# Altium schematic sheet EE3951W_Scale.SchDoc
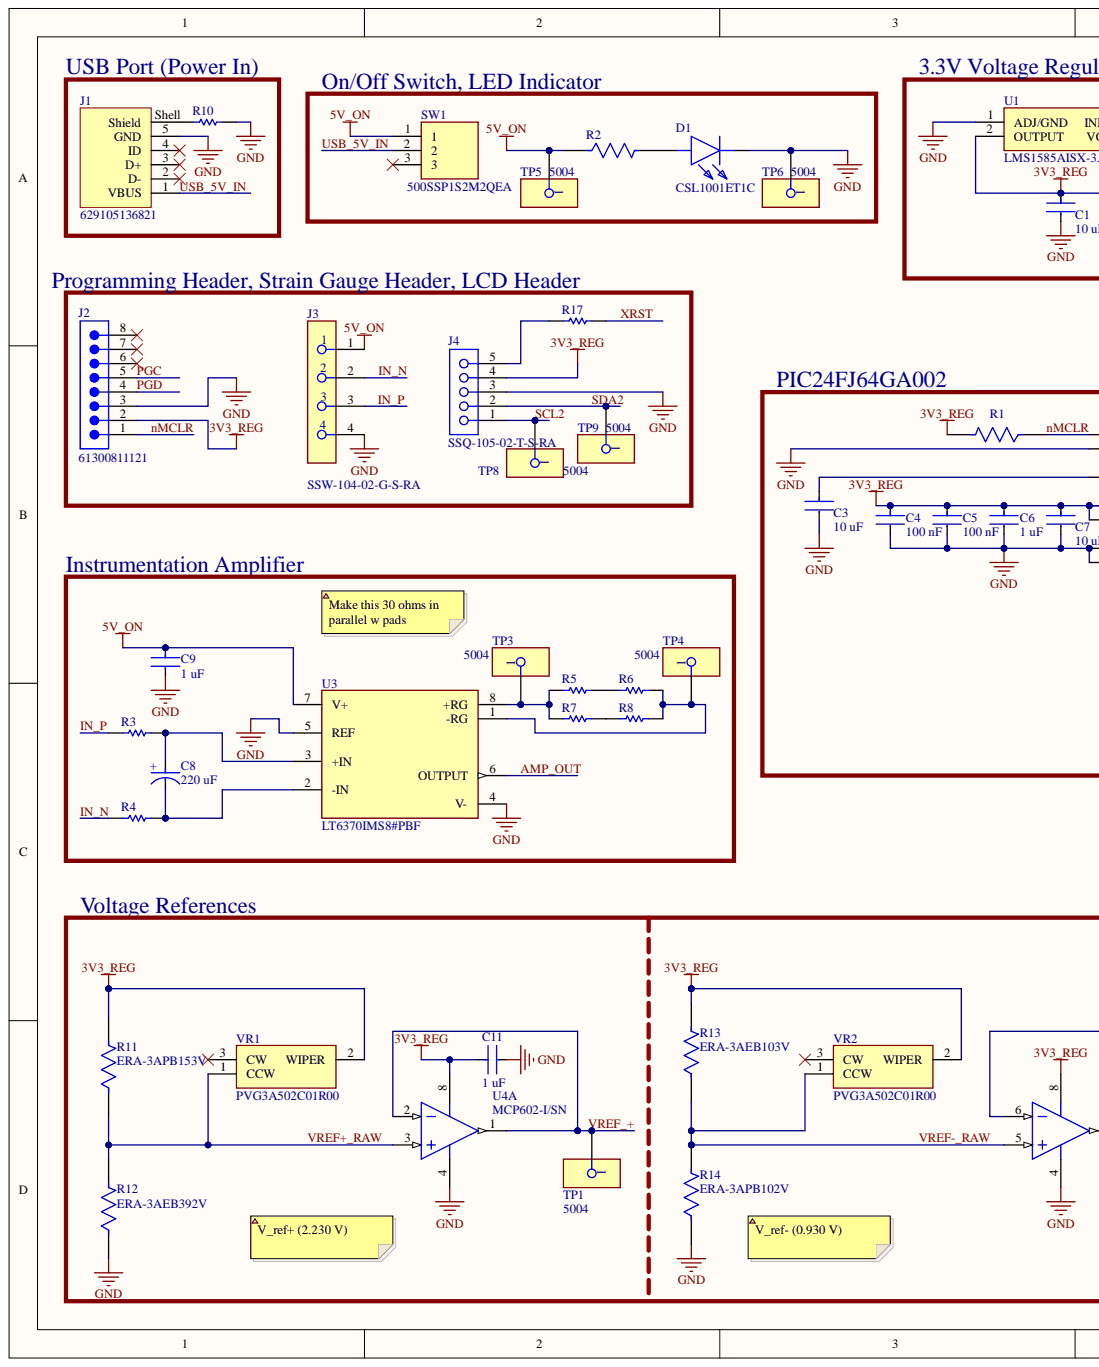
[diagram p0: EE3951W_Scale.SchDoc, left]
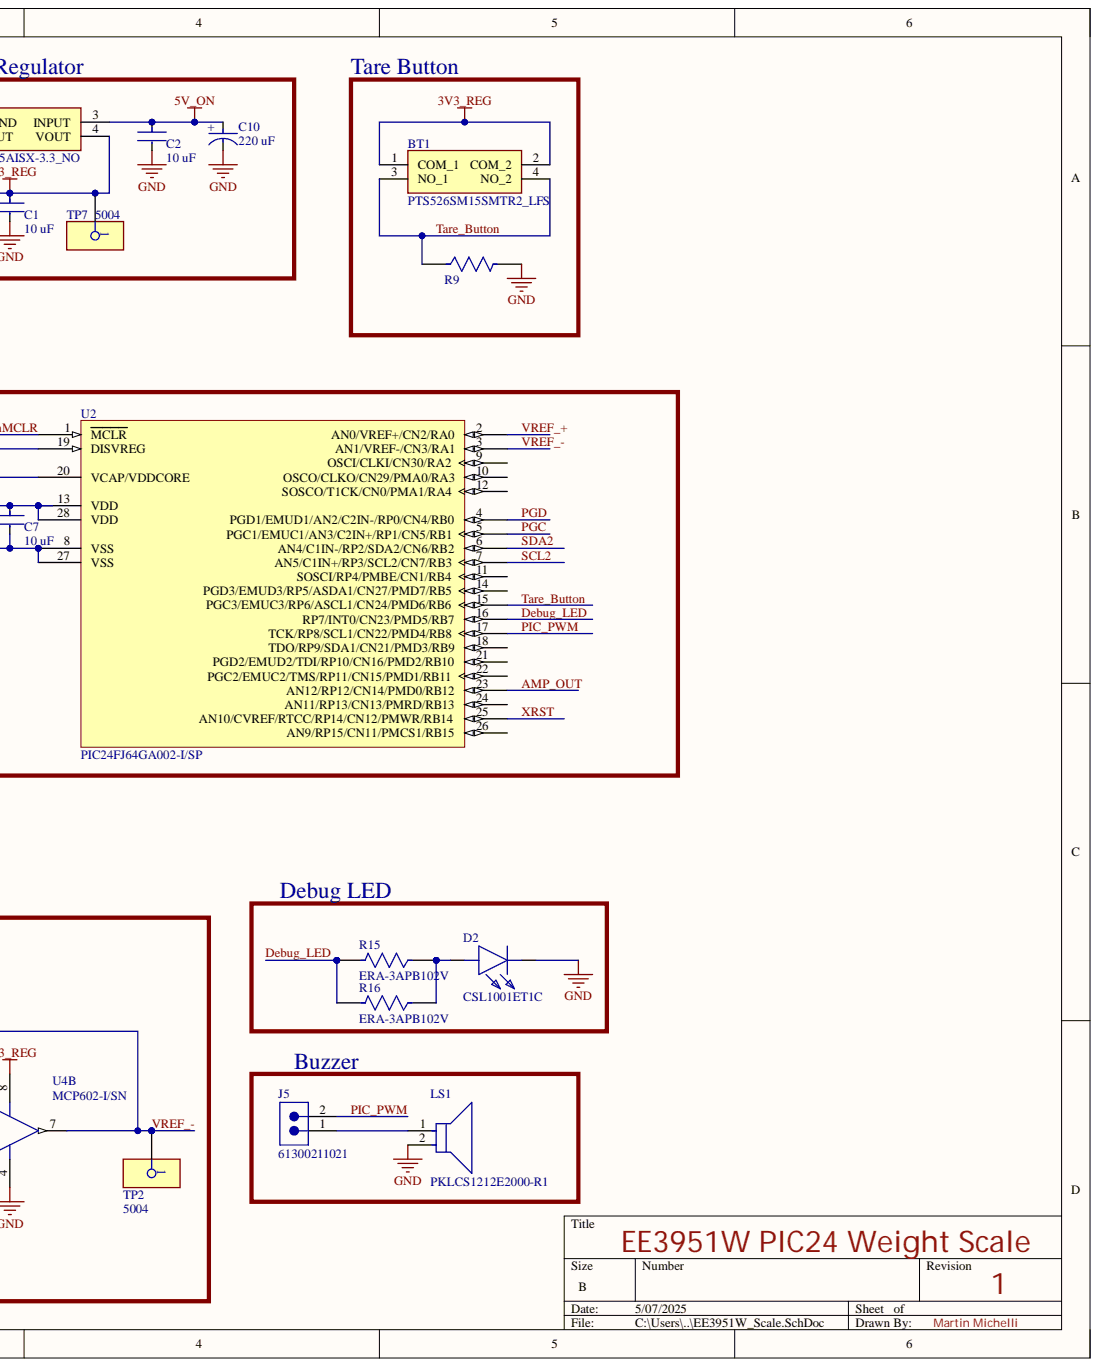
[diagram p1: EE3951W_Scale.SchDoc, right]

## Parts
BT1  PTS526SM15SMTR2_LFS  [PTS526SM15SMTR2LFS]
C1  10 uF  [FP-GRM188-0_1-e0_2_0_55-IPC_A]
C2  10 uF  [FP-GRM188-0_1-e0_2_0_55-IPC_A]
C3  10 uF  [FP-GRM188-0_1-e0_2_0_55-IPC_A]
C4  100 nF  [FP-GRM188-0_1-e0_2_0_5-IPC_B]
C5  100 nF  [FP-GRM188-0_1-e0_2_0_5-IPC_B]
C6  1 uF  [FP-GCM188-0_1-MFG]
C7  10 uF  [FP-GRM188-0_1-e0_2_0_55-IPC_A]
C8  220 uF  [FP-TAJC-MFG]
C9  1 uF  [FP-GCM188-0_1-MFG]
C10  220 uF  [FP-TAJC-MFG]
C11  1 uF  [FP-GCM188-0_1-MFG]
D1  CSL1001ET1C  [CSL1001ET1C]
D2  CSL1001ET1C  [CSL1001ET1C]
J1  629105136821  Connector Type: USB  [629105136821]
J2  61300811121  [61300811121]
J3  SSW-104-02-G-S-RA  [FP-SSW-104-02-G-S-RA-MFG]
J4  SSQ-105-02-T-S-RA  [SMTC-SSQ-105-02-X-S-RA]
J5  61300211021  [61300211021]
LS1  PKLCS1212E2000-R1  [FP-PKLCS1212E2000-R1-MFG]
R1  RC0603FR-0710KL  [FP-RC0603-0_55-IPC_C]  (value not drawn)
R2  RC0603FR-071KL  [FP-RC0603-0_55-IPC_C]  (value not drawn)
R3  RC0603JR-070RL  [RESC1608X55X25ML10T15]  (value not drawn)
R4  RC0603JR-070RL  [RESC1608X55X25ML10T15]  (value not drawn)
R5  RC0603JR-070RL  [RESC1608X55X25ML10T15]  (value not drawn)
R6  RC0603JR-070RL  [RESC1608X55X25ML10T15]  (value not drawn)
R7  RC0603JR-070RL  [RESC1608X55X25ML10T15]  (value not drawn)
R8  RC0603JR-070RL  [RESC1608X55X25ML10T15]  (value not drawn)
R9  RC0603FR-0710KL  [FP-RC0603-0_55-IPC_C]  (value not drawn)
R10  RC0603JR-070RL  [RESC1608X55X25ML10T15]  (value not drawn)
R11  ERA-3APB153V  [FP-ERA3A-IPC_C]
R12  ERA-3AEB392V  [FP-ERA3A-IPC_C]
R13  ERA-3AEB103V  [FP-ERA3A-IPC_C]
R14  ERA-3APB102V  [FP-ERA3A-IPC_C]
R15  ERA-3APB102V  [FP-ERA3A-IPC_C]
R16  ERA-3APB102V  [FP-ERA3A-IPC_C]
R17  RC0603JR-070RL  [RESC1608X55X25ML10T15]  (value not drawn)
SW1  500SSP1S2M2QEA  [500SSP1S2M2QEA]
TP1  5004  [FP-5004-MFG]
TP2  5004  [FP-5004-MFG]
TP3  5004  [FP-5004-MFG]
TP4  5004  [FP-5004-MFG]
TP5  5004  [FP-5004-MFG]
TP6  5004  [FP-5004-MFG]
TP7  5004  [FP-5004-MFG]
TP8  5004  [FP-5004-MFG]
TP9  5004  [FP-5004-MFG]
U1  LMS1585AISX-3.3_NO  [KTT0003B]
U2  PIC24FJ64GA002-I/SP  [SPDIP300-SP28]  (value not drawn)
U3  LT6370IMS8#PBF  [FP-MS8-8-05-08-1660-IPC_B]
U4  MCP602-I/SN  [SOIC-SN8_M]  (parts A,B)
VR1  PVG3A502C01R00  [PVG3A502C01R00]
VR2  PVG3A502C01R00  [PVG3A502C01R00]

## Nets
3V3_REG: BT1.1(COM_1) BT1.2(COM_2) C1.1 C4.1 C5.1 C6.1 C7.1 C11.1 J2.2 J4.4 R1.2 R11.2 R13.2 TP7.1 U1.2(OUTPUT) U1.4(VOUT) U2.13(VDD) U2.28(VDD) U4.8 VR1.2 VR2.2
5V_ON: C2.1 C9.1 C10.2 J3.1 R2.1 SW1.1 TP5.1 U1.3(INPUT) U3.7(V+)
GND: C1.2 C2.2 C3.2 C4.2 C5.2 C6.2 C7.2 C9.2 C10.1 C11.2 D1.1 D2.1 J1.5(GND) J2.3 J3.4 J4.3 LS1.2 R9.2 R10.2 R12.1 R14.1 TP6.1 U1.1(ADJ/GND) U2.8(VSS) U2.19(DISVREG) U2.27(VSS) U3.4(V-) U3.5(REF) U4.4
AMP_OUT: U2.23(AN12/RP12/CN14/PMD0/RB12) U3.6(OUTPUT)
Debug_LED: R15.2 R16.2 U2.16(RP7/INT0/CN23/PMD5/RB7)
IN_N: J3.2 R4.1
IN_P: J3.3 R3.1
nMCLR: J2.1 R1.1 U2.1(MCLR)
PGC: J2.5 U2.5(PGC1/EMUC1/AN3/C2IN+/RP1/CN5/RB1)
PGD: J2.4 U2.4(PGD1/EMUD1/AN2/C2IN-/RP0/CN4/RB0)
PIC_PWM: J5.2 U2.17(TCK/RP8/SCL1/CN22/PMD4/RB8)
SCL2: J4.1 TP8.1 U2.7(AN5/C1IN+/RP3/SCL2/CN7/RB3)
SDA2: J4.2 TP9.1 U2.6(AN4/C1IN-/RP2/SDA2/CN6/RB2)
Tare_Button: BT1.3(NO_1) BT1.4(NO_2) R9.1 U2.15(PGC3/EMUC3/RP6/ASCL1/CN24/PMD6/RB6)
USB_5V_IN: J1.1(VBUS) SW1.2
VREF+_RAW: R11.1 R12.2 U4.3 VR1.1
VREF-_RAW: R13.1 R14.2 U4.5 VR2.1
VREF_+: TP1.1 U2.2(AN0/VREF+/CN2/RA0) U4.1 U4.2
VREF_-: TP2.1 U2.3(AN1/VREF-/CN3/RA1) U4.6 U4.7
XRST: R17.2 U2.25(AN10/CVREF/RTCC/RP14/CN12/PMWR/RB14)
NetC3_1: C3.1 U2.20(VCAP/VDDCORE)
NetC8_1: C8.1 R4.2 U3.2(-IN)
NetC8_2: C8.2 R3.2 U3.3(+IN)
NetD1_2: D1.2 R2.2
NetD2_2: D2.2 R15.1 R16.1
NetJ1_Shell: J1.Shell(Shield) R10.1
NetJ4_5: J4.5 R17.1
NetJ5_1: J5.1 LS1.1
NetR5_1: R5.1 R7.1 TP3.1 U3.8(+RG)
NetR5_2: R5.2 R6.1
NetR6_2: R6.2 R8.2 TP4.1 U3.1(-RG)
NetR7_2: R7.2 R8.1

Not connected: J1 2(D-) 3(D+) 4(ID); J2 6 7 8; SW1 3; U2 9(OSCI/CLKI/CN30/RA2) 10(OSCO/CLKO/CN29/PMA0/RA3) 11(SOSCI/RP4/PMBE/CN1/RB4) 12(SOSCO/T1CK/CN0/PMA1/RA4) 14(PGD3/EMUD3/RP5/ASDA1/CN27/PMD7/RB5) 18(TDO/RP9/SDA1/CN21/PMD3/RB9) 21(PGD2/EMUD2/TDI/RP10/CN16/PMD2/RB10) 22(PGC2/EMUC2/TMS/RP11/CN15/PMD1/RB11) 24(AN11/RP13/CN13/PMRD/RB13) 26(AN9/RP15/CN11/PMCS1/RB15); VR1 3; VR2 3

## Library data of the parts
BT1 = PTS526SM15SMTR2_LFS: Switch; Manufacturer_Name: C & K COMPONENTS; Manufacturer_Part_Number: PTS526SM15SMTR2 LFS; Component Kind: Standard; Datasheet Link: https://www.ckswitches.com/media/2780/pts526.pdf; Height: 1.65mm
C1-C3 C7 = CMP-2006-02792-2: [GRM188R60J106ME47D] Chip Multilayer Ceramic Capacitors for General Purpose, 0603, 10uF, X5R, 15%, 20%, 6.3V; Capacitance: 10uF; Case Code (Imperial): 0603; Case Code (Metric): 1608; Case/Package: 0603; Component Kind: Standard; Depth: 0.8mm; Dielectric: X5R; Height: 0.8mm; Lead Free: Lead Free; Length: 1.6mm; Library Name: Altium Content Vault; Material: Ceramic; Max Operating Temperature: 85°C; Min Operating Temperature: -55°C; Mount: Surface Mount; Package Quantity: 4000; Packaging: Tape and Reel; Radiation Hardening: No; REACH SVHC: No SVHC; Termination: SMD/SMT; Thickness: 0.035inch; Tolerance: 20%; Voltage: 6.3V; Voltage Rating: 6.3V; Voltage Rating (DC): 6.3V; Width: 0.031inch
C4 C5 = CMP-1035-02595-8: [GRM188R72A104KA35D] Chip Multilayer Ceramic Capacitors for General Purpose, 0603, 0.10uF, X7R, 15%, 10%, 100V; Capacitance: 100nF; Case Code (Imperial): 0603; Case Code (Metric): 1608; Case/Package: 0603; Component Kind: Standard; Depth: 0.8mm; Dielectric: X7R; Height: 0.8mm; Lead Free: Lead Free; Length: 1.6mm; Library Name: Altium Content Vault; Material: Ceramic; Max Operating Temperature: 125°C; Min Operating Temperature: -55°C; Mount: Surface Mount; Package Quantity: 1; Packaging: Tape and Reel; Radiation Hardening: No; REACH SVHC: No SVHC; Termination: SMD/SMT; Thickness: 0.035inch; Tolerance: 10%; Voltage: 100V; Voltage Rating: 100V; Voltage Rating (DC): 100V; Weight: 0.000222oz; Width: 0.8mm
C6 C9 C11 = CMP-2006-02363-4: [GCM188R71E105KA64D] 1 µF ±10% 25V Ceramic Capacitor X7R 0603 (1608 Metric); Manufacturer Lifecycle Status: IN PRODUCTION; NexarPartId: 18909256; Capacitance: 1µF; Case Code (Imperial): 0603; Case Code (Metric): 1608; Case/Package: 0603; Component Kind: Standard; Depth: 800µm; Dielectric: X7R; Height: 800µm; Lead Free: Lead Free; Length: 1.6mm; Library Name: Altium Content Vault; Lifecycle Status: Production; Material: Ceramic; Max Operating Temperature: 125°C; Min Operating Temperature: -55°C; Mount: Surface Mount; Packaging: Cut Tape; Radiation Hardening: No; Ratings: AEC-Q200; REACH SVHC: No SVHC; RoHS: Compliant; Schedule B: 8532240020, 8532240020; Termination: SMD/SMT; Thickness: 889µm; Tolerance: 10%; Voltage: 25V; Voltage Rating: 25V; Voltage Rating (DC): 25V; Weight: 6.293594mg; Width: 787.4µm
C8 C10 = CMP-04424-000472-1: (TAJC227K006RNJ) 220uF 6.3V  ±10% 1.2Ω 2312  SMD Tantalum Capacitor; Dissipation Factor: 8%; ESR (Equivalent Series Resistance): 1.2R; Leakage Current: 13.9uA; Polarity: Polar; Capacitance: 220uF; Case Code (Imperial): 2312; Case Code (Metric): 6032; Case/Package: 2412; Component Kind: Standard; Construction: Flat; Depth: 3.2mm; Dielectric Material: Tantalum; Features: General Purpose; Height: 2.6mm; Height - Seated (Max): 0.11inch; Lead Free: Lead Free; Length: 6mm; Library Name: Altium Content Vault; Max Operating Temperature: 125°C; Min Operating Temperature: -55°C; Mount: Surface Mount; Number of Pins: 2; Package Quantity: 500; Packaging: Tape and Reel; Radiation Hardening: No; REACH SVHC: No SVHC; RoHS Compliant: Yes; Series: TAJ; Termination: SMD/SMT; Tolerance: 10%; Voltage: 6.3V; Voltage Rating: 6.3V; Voltage Rating (DC): 6.3V; Width: 3.2mm
D1 D2 = CSL1001ET1C: LED; Manufacturer_Name: ROHM Semiconductor; Component Kind: Standard; Datasheet Link: https://fscdn.rohm.com/en/products/databook/datasheet/opto/led/chip_mono/csl1001et1(c)-e.pdf; Height: 1.16mm; Manufacturer_Part_Number: CSL1001ET1C; Mouser Part Number: 755-CSL1001ET1C; Mouser Price/Stock: https://www.mouser.co.uk/ProductDetail/ROHM-Semiconductor/CSL1001ET1C?qs=HoCaDK9Nz5fkfrz07fjv7w%3D%3D; Library Name: Avionics.SchLib
J1 = CMP-1502-03253-2: Micro-USB Header; NexarPartId: 19991173; Contact Resistance: 30mΩ; Current Rating: 1A; Gender: Receptacle; Insulation Resistance: 1GΩ; Number of Contacts: 5; Number of Ports: 1; Operating Supply Voltage: 30V; Plating: Nickel; USB Standard: USB 2.0; Color: Black; Component Kind: Standard; Contact Material: Copper; Contact Plating: Gold; Flammability Rating: UL94 V-0; Height: 2.9mm; Lead Free: Lead Free; Length: 5.9mm; Library Name: Altium Content Vault; Max Operating Temperature: 105°C; Min Operating Temperature: -40°C; Mount: Horizontal, Surface Mount; Number of Pins: 5; Number of Positions: 5; Orientation: Horizontal; Packaging: Tape and Reel; REACH SVHC: Unknown; RoHS: Compliant; Termination: Solder; Voltage Rating: 30V
J2 = CMP-1502-01076-2: NexarPartId: 32855533; Connector Type: Male Pin; Contact Resistance: 20mΩ; Current Rating: 3A; Gender: Male; Number of Contacts: 8; Operating Supply Voltage: 250V; Pitch: 2.54mm; Color: Black; Component Kind: Standard; Contact Material: Copper; Contact Mating Length: 5.9944mm; Contact Plating: Gold; Height: 8.54mm; Lead Pitch: 2.54mm; Library Name: Altium Content Vault; Max Operating Temperature: 105°C; Min Operating Temperature: -40°C; Mount: Through Hole; Number of Pins: 8; Number of Positions: 8; Number of Rows: 1; Orientation: Vertical; Packaging: Bulk; REACH SVHC: Unknown; RoHS: Compliant; Termination: Solder; Voltage Rating: 250V
J3 = CMP-00575-00296849-1: SSW-104-02-G-S-RA Headers & Wire Housings Tiger Buy Socket Strip with PCB Tails, .100 Pitch; Manufacturer Lifecycle Status: PRODUCTION; NexarPartId: 296849; Connector Type: Receptacle, Socket; Contact Resistance: 10mΩ; Current Rating: 6.9A; Gender: Receptacle; Lead Length: 2.54mm; Max Voltage Rating (AC): 550V; Number of Contacts: 4; Voltage Rating (AC): 465V; Voltage Rating (DC): 655V; Color: Black; Component Kind: Standard; Contact Material: Bronze; Contact Plating: Gold; Depth: 10.03mm; Housing Color: Black; Housing Material: Polymer; Lead Free: Lead Free; Lead Pitch: 2.54mm; Length: 10.67mm; Library Name: Altium Content Vault; Lifecycle Status: Production; Max Current Rating: 6.9A; Max Operating Temperature: 125°C; Min Operating Temperature: -55°C; Mount: Through Hole; Number of Positions: 4; Number of Rows: 1; Orientation: Right Angle; Packaging: Bulk; Pitch: 2.54mm; Radiation Hardening: No; REACH SVHC: No SVHC; RoHS: Compliant; Termination: Solder; Voltage Rating: 550V
J4 = CMP-1023-00281-1: SQ Post Socket, Through-hole, Right Angle, -55 to 105 degC, 2.54 mm Pitch, 5-Pin, Female, RoHS; Manufacturer: Samtec; Pitch: 2.54 mm; Component Kind: Standard; ComponentLink1Description: Manufacturer URL; ComponentLink1URL: http://www.samtec.com; ComponentLink2Description: Datasheet; ComponentLink2URL: http://www.samtec.com/documents/webfiles/pdf/SSQ_TH.PDF; ComponentLink3Description: Package Specification; ComponentLink3URL: http://www.samtec.com/documents/webfiles/cpdf/SSQ-1XX-XX-XXX-X-XX-XXX-XX-X-MKT.pdf; DatasheetVersion: F-214; Library Name: Altium Content Vault; Mounting Technology: Through-hole; Orientation: Right Angle; PackageDescription: Assembly, Socket Strip .025[0.64] SQ Tail; PackageReference: SSQ-105-02-X-S-RA; PackageVersion: BD, 6/1987; PartNumber: SSQ-105-02-T-S-RA; RoHS: True; Temperature Range: -55 to 105 degC
J5 = CMP-1502-01059-3: NexarPartId: 32855519; Connector Type: Male Pin; Contact Resistance: 20mΩ; Current Rating: 3A; Gender: Male; Number of Contacts: 2; Operating Supply Voltage: 250V; Pitch: 2.54mm; Color: Black; Component Kind: Standard; Contact Material: Copper; Contact Mating Length: 5.9944mm; Contact Plating: Gold; Lead Pitch: 2.54mm; Library Name: Altium Content Vault; Mount: Through Hole; Number of Pins: 2; Number of Positions: 2; Number of Rows: 1; Packaging: Bulk; REACH SVHC: Unknown; RoHS: Compliant; Termination: Solder
LS1 = CMP-06202-000001-1: AUDIO PIEZO TRANSDUCER 12.5V SMD; Manufacturer: Murata; Frequency: 2kHz; Automotive: No; Category: Speak; Component Kind: Standard; ComponentLink2Description: Datasheet; ComponentLink2URL: https://www.murata.com/en-global/products/productdata/8801054425118/SPEC-PKLCS1212E2000-R1.pdf?1517839212000; Device Class L1: Electromechanical; Device Class L2: Audio Products; Device Class L3: Buzzers; Digikey Description: AUDIO PIEZO TRANSDUCER 12.5V SMD; Height: 3mm; Lead Free: Yes; Library Name: Altium Content Vault; Manufacturer Part Number: PKLCS1212E2000-R1; Mouser Description: Piezo buzzer SMD; Octopart: https://octopart.com/pklcs1212e2000-r1-murata-7123179; Operating Supply Voltage: 12.5V; Package: PKLCS1212E2000-R1; RoHS: TRUE; Temperature: -20°C to +70°C; Temperature Range High: +70°C; Temperature Range Low: -20°C
R1 R9 = CMP-2100-03664-2: RES 10K OHM 1% 1/10W 0603; Max Power Dissipation: 100mW; Resistance: 10kR; Temperature Coefficient: 100ppm/°C; Voltage Rating: 75V; Voltage Rating (DC): 50V; Case Code (Imperial): 0603; Case Code (Metric): 1608; Case/Package: 0603; Component Kind: Standard; Construction: Rectangular; Depth: 0.8mm; Features: Moisture Resistant; Height: 0.45mm; Lead Free: Lead Free; Lead Pitch: 0.3mm; Length: 1.6mm; Library Name: Altium Content Vault; Max Operating Temperature: 155°C; Military Standard: Not; Min Operating Temperature: -55°C; Number of Pins: 2; Number of Terminations: 2; Packaging: Tape and Reel; Power Rating: 100mW; Resistance Tolerance: 1%; Resistor Type: Thick Film; RoHS Compliant: Yes; Series: RC; Size Code: 0603; Termination: SMD/SMT; Tolerance: 1%; Weight: 7.1E-05oz; Width: 0.8mm
R2 = CMP-1659-00009-5: RES 1K OHM 1% 1/10W 0603; Max Power Dissipation: 100mW; Resistance: 1kR; Temperature Coefficient: 100ppm/°C; Voltage Rating: 50V; Case Code (Imperial): 0603; Case Code (Metric): 1608; Case/Package: 0603; Component Kind: Standard; Construction: Rectangular; Contact Plating: Tin; Depth: 0.8mm; Features: Moisture Resistant; Height: 0.45mm; Lead Free: Lead Free; Length: 1.6mm; Library Name: Altium Content Vault; Max Operating Temperature: 155°C; Military Standard: Not; Min Operating Temperature: -55°C; Number of Terminations: 2; Packaging: Tape and Reel; Power Rating: 100mW; Radiation Hardening: No; REACH SVHC: No SVHC; Resistance Tolerance: 1%; Resistor Type: Thick Film; RoHS Compliant: Yes; Series: RC; Size Code: 0603; Technology: THICK FILM; Termination: SMD/SMT; Tolerance: 1%
R3-R8 R10 R17 = CMP-2100-03667-1: Chip Resistor, 0 Ohm, +/- 5%, 0.1 W, -55 to 155 degC, 0603 (1608 Metric), RoHS, Tape and Reel; Manufacturer: Yageo; Power Rating: 100mW; Resistance: 0mOhm; Temperature Coefficient: 200ppm/degreesC; Voltage Rating: 50V; Case Code (Imperial): 0603; Case Code (Metric): 1608; Case/Package: 0603; Component Kind: Standard; Composition: ThickFilm; Contact Plating: Tin; Datasheet URL: http://www.yageo.com/NewPortal/yageodocoutput?fileName=/pdf/R-Chip/PYu-RC_Group_51_RoHS_L_5.pdf; Datasheet Version: Rev. 5, 10/2016; Depth: 0.8mm; Height: 0.45mm; Lead Free: LeadFree; Length: 1.6mm; Library Name: Altium Content Vault; Manufacturer URL: http://www.yageo.com/; Max Operating Temperature: 155degC; Military Standard: Not; Min Operating Temperature: -55degC; Number of Pins: 2; Package Description: 2-Pin Surface Mount Device, Body 1.6 x 0.8 mm; Package Quantity: 5000; Package Reference: 0603; Packaging: TapeandReel; Radiation Hardening: No; REACH SVHC: NoSVHC; RoHSCompliant: true; Termination: SMD/SMT; Tolerance: 5%; Voltage Rating (DC): 50V; Width: 0.8mm
R11 = CMP-2000-00874-2: RES SMD 15K OHM 0.1% 1/10W 0603; Max Power Dissipation: 100mW; Resistance: 10kR; Temperature Coefficient: 25ppm/°C; Voltage Rating: 75V; Case Code (Imperial): 0603; Case Code (Metric): 1608; Case/Package: 0603; Component Kind: Standard; Construction: Rectangular; Contact Plating: Tin; Depth: 0.8mm; Height: 0.45mm; Lead Free: Lead Free; Length: 1.6mm; Library Name: Altium Content Vault; Max Operating Temperature: 155°C; Military Standard: Not; Min Operating Temperature: -55°C; Mount: Surface Mount; Number of Pins: 2; Number of Terminations: 2; Package Quantity: 5000; Packaging: Tape and Reel; Power Rating: 100mW; Radiation Hardening: No; REACH SVHC: No SVHC; Resistance Tolerance: 0.1%; Resistor Type: Thin Film; RoHS Compliant: Yes; Series: ERA-3A; Size Code: 0603; Technology: METAL FILM; Termination: SMD/SMT; Tolerance: 0.1%; Voltage Rating (DC): 75V; Width: 0.8mm
R12 = CMP-2000-00874-2: RES SMD 3.9K OHM 0.1% 1/10W 0603; Max Power Dissipation: 100mW; Resistance: 10kR; Temperature Coefficient: 25ppm/°C; Voltage Rating: 75V; Case Code (Imperial): 0603; Case Code (Metric): 1608; Case/Package: 0603; Component Kind: Standard; Construction: Rectangular; Contact Plating: Tin; Depth: 0.8mm; Height: 0.45mm; Lead Free: Lead Free; Length: 1.6mm; Library Name: Altium Content Vault; Max Operating Temperature: 155°C; Military Standard: Not; Min Operating Temperature: -55°C; Mount: Surface Mount; Number of Pins: 2; Number of Terminations: 2; Package Quantity: 5000; Packaging: Tape and Reel; Power Rating: 100mW; Radiation Hardening: No; REACH SVHC: No SVHC; Resistance Tolerance: 0.1%; Resistor Type: Thin Film; RoHS Compliant: Yes; Series: ERA-3A; Size Code: 0603; Technology: METAL FILM; Termination: SMD/SMT; Tolerance: 0.1%; Voltage Rating (DC): 75V; Width: 0.8mm
R13 = CMP-2000-00874-2: RES SMD 10K OHM 0.1% 1/10W 0603; Max Power Dissipation: 100mW; Resistance: 10kR; Temperature Coefficient: 25ppm/°C; Voltage Rating: 75V; Case Code (Imperial): 0603; Case Code (Metric): 1608; Case/Package: 0603; Component Kind: Standard; Construction: Rectangular; Contact Plating: Tin; Depth: 0.8mm; Height: 0.45mm; Lead Free: Lead Free; Length: 1.6mm; Library Name: Altium Content Vault; Max Operating Temperature: 155°C; Military Standard: Not; Min Operating Temperature: -55°C; Mount: Surface Mount; Number of Pins: 2; Number of Terminations: 2; Package Quantity: 5000; Packaging: Tape and Reel; Power Rating: 100mW; Radiation Hardening: No; REACH SVHC: No SVHC; Resistance Tolerance: 0.1%; Resistor Type: Thin Film; RoHS Compliant: Yes; Series: ERA-3A; Size Code: 0603; Technology: METAL FILM; Termination: SMD/SMT; Tolerance: 0.1%; Voltage Rating (DC): 75V; Width: 0.8mm
R14-R16 = CMP-2000-00874-2: RES SMD 1K OHM 0.1% 1/10W 0603; Max Power Dissipation: 100mW; Resistance: 10kR; Temperature Coefficient: 25ppm/°C; Voltage Rating: 75V; Case Code (Imperial): 0603; Case Code (Metric): 1608; Case/Package: 0603; Component Kind: Standard; Construction: Rectangular; Contact Plating: Tin; Depth: 0.8mm; Height: 0.45mm; Lead Free: Lead Free; Length: 1.6mm; Library Name: Altium Content Vault; Max Operating Temperature: 155°C; Military Standard: Not; Min Operating Temperature: -55°C; Mount: Surface Mount; Number of Pins: 2; Number of Terminations: 2; Package Quantity: 5000; Packaging: Tape and Reel; Power Rating: 100mW; Radiation Hardening: No; REACH SVHC: No SVHC; Resistance Tolerance: 0.1%; Resistor Type: Thin Film; RoHS Compliant: Yes; Series: ERA-3A; Size Code: 0603; Technology: METAL FILM; Termination: SMD/SMT; Tolerance: 0.1%; Voltage Rating (DC): 75V; Width: 0.8mm
SW1 = 500SSP1S2M2QEA: Switch 5A 28VDC; Manufacturer_Name: E-Switch; Component Kind: Standard; Datasheet Link: https://configured-product-images.s3.amazonaws.com/2D/specs/500SSP1S2M2QEA.pdf; Height: 6.6mm; Manufacturer_Part_Number: 500SSP1S2M2QEA; Mouser Part Number: 612-500SSP1S2M2QEA; Mouser Price/Stock: https://www.mouser.co.uk/ProductDetail/E-Switch/500SSP1S2M2QEA?qs=f57gQzlyLipU%252BL4xPBemOw%3D%3D
TP1-TP9 = CMP-002-00078-1: Interconnection Device; Component Kind: Standard; Connector Type: Interconnection Device; Digikey Part Number: 36-5004-ND; RoHS Compliant: Yes; Library Name: University of Minnesota
U1 = LMS1585AISX-3.3_NO: FIXED 3.3V LINEAR REGULATOR 5A DDPAK/TO263-3; Manufacturer_Name: Texas Instruments; Manufacturer_Part_Number: LMS1585AISX-3.3/NO; Arrow Part Number: LMS1585AISX-3.3/NO; Arrow Price/Stock: https://www.arrow.com/en/products/lms1585aisx-3.3no/texas-instruments?region=nac; Component Kind: Standard; Datasheet Link: http://www.ti.com/lit/gpn/LMS1585A; Mouser Part Number: 595-LMS1585AISX33/NO; Mouser Price/Stock: https://www.mouser.co.uk/ProductDetail/Texas-Instruments/LMS1585AISX-3.3-NO?qs=Mv7BduZupUgcTszQb%252BDdgQ%3D%3D
U2 = CMP-0046-00003-2: General Purpose 16-Bit Flash Microcontroller, 64KB Flash, 8KB SRAM, 28-Pin SPDIP, Industrial Temperature; Component Kind: Standard; ComponentLink1Description: Datasheet; ComponentLink1URL: http://ww1.microchip.com/downloads/en/DeviceDoc/39881D.pdf; ComponentLink2Description: Packaging Specification; ComponentLink2URL: http://www.microchip.com/stellent/groups/techpub_sg/documents/packagingspec/en012702.pdf; ComponentLink3Description: Microchip.com Home; ComponentLink3URL: http://www.microchip.com/; DatasheetVersion: revD, Feb-2010; Library Name: Altium Content Vault; PackageDescription: 28-Lead Skinny Plastic Dual In-Line (SP) - 300 mil Body [SPDIP]; PackageReference: SPDIP300-SP28; PackageVersion: revBB, Aug-2009; RevisionNote: Component URLs added.
U3 = CMP-09116-000380-1: IC INST AMP 1 CIRCUIT 8MSOP; Component Kind: Standard; Library Name: Altium Content Vault
U4 = CMP-0187-00026-3: None; Amplifier Type: GENERAL PURPOSE; Bandwidth: 2.8MHz; Common Mode Rejection Ratio: 75dB; Input Bias Current: 1pA; Input Offset Voltage (Vos): 2mV; Input Offset Voltage-Max: 3mV; Max Supply Voltage: 6V; Min Supply Voltage: 2.7V; Nominal Supply Current: 230uA; Number of Amplifiers: 2; Operating Supply Voltage: 5.5V; Output Current: 22mA; Power Supply Rejection Ratio (PSRR): 80dB; Slew Rate: 2.3V/µs; Voltage: 7V; Voltage Gain: 115dB; Case/Package: SOIC; Component Kind: Standard; Gain Bandwidth Product: 2.8MHz; Height: 1.25mm; Lead Free: Lead Free; Length: 4.9mm; Library Name: Altium Content Vault; Max Operating Temperature: 85°C; Min Operating Temperature: -40°C; Mount: Surface Mount; Number of Channels: 2; Number of Circuits: 2; Number of Elements: 2; Number of Pins: 8; Package Quantity: 100; Packaging: Rail/Tube; Radiation Hardening: No; REACH SVHC: No SVHC; RoHS Compliant: Yes; Slew Rate-Nom: 2.3V/µs; Supply Current: 230uA; Technology: CMOS; Unity Gain Bandwidth Product: 2.8MHz; Width: 3.9mm
VR1 VR2 = PVG3A502C01R00: (5 KOhm) Variable Resistor; Manufacturer_Name: Bourns; Arrow Part Number: PVG3A502C01R00; Arrow Price/Stock: https://www.arrow.com/en/products/pvg3a502c01r00/bourns?region=nac; Component Kind: Standard; Datasheet Link: https://datasheet.datasheetarchive.com/originals/dk/DKDS-12/224986.pdf; Height: 2mm; Manufacturer_Part_Number: PVG3A502C01R00; Mouser Part Number: 81-PVG3A502C01R00; Mouser Price/Stock: https://www.mouser.co.uk/ProductDetail/Bourns/PVG3A502C01R00?qs=M0b1YOHIqsYnRhxDDNb4cw%3D%3D
